# Altium schematic sheet Motor and ToF.SchDoc
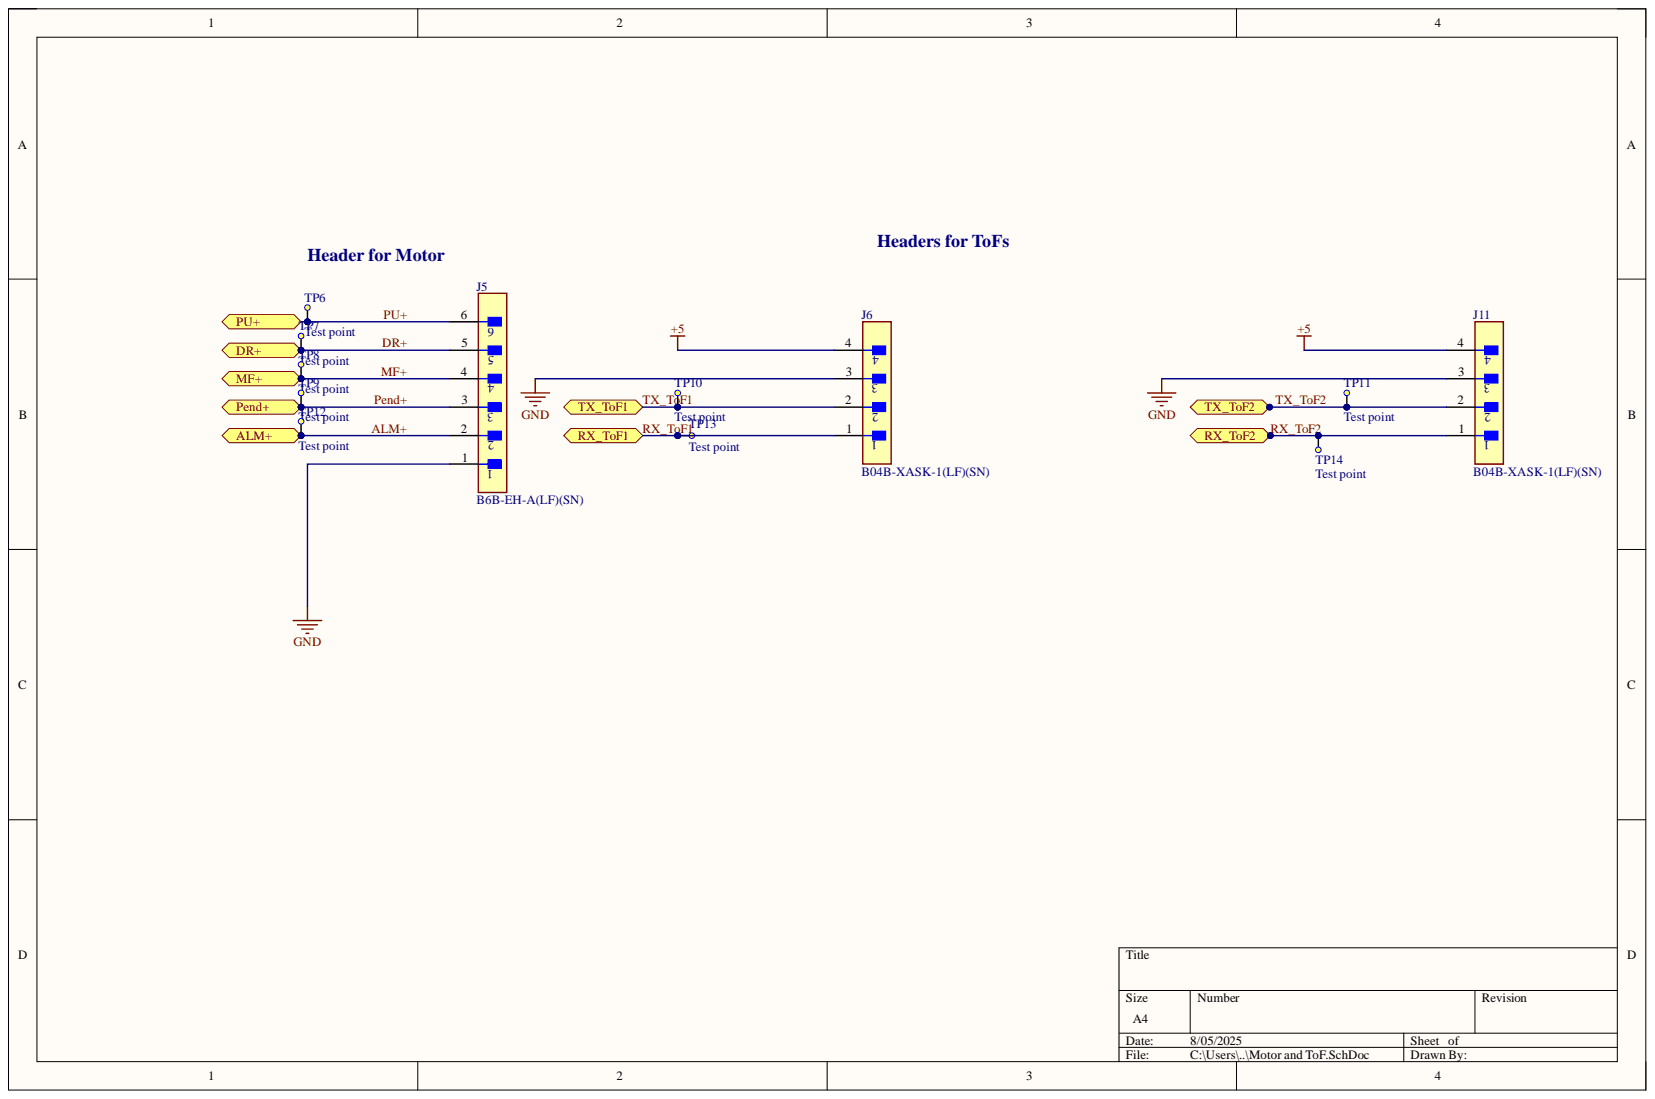
[diagram p0: Motor and ToF.SchDoc]

## Parts
J5  B6B-EH-A(LF)(SN)  [FP-B6B-EH-A_LF_SN-MFG]
J6  B04B-XASK-1(LF)(SN)  [FP-B04B-XASK-1_LF_SN-MFG]
J11  B04B-XASK-1(LF)(SN)  [FP-B04B-XASK-1_LF_SN-MFG]
TP6  Test point  [Test_point]
TP7  Test point  [Test_point]
TP8  Test point  [Test_point]
TP9  Test point  [Test_point]
TP10  Test point  [Test_point]
TP11  Test point  [Test_point]
TP12  Test point  [Test_point]
TP13  Test point  [Test_point]
TP14  Test point  [Test_point]

## Ports
ALM+, DR+, MF+, Pend+, PU+, RX_ToF1, RX_ToF2, TX_ToF1, TX_ToF2

## Nets
+5: J6.4 J11.4
GND: J5.1 J6.3 J11.3
ALM+: J5.2 TP12.TP? ; port ALM+
DR+: J5.5 TP7.TP? ; port DR+
MF+: J5.4 TP8.TP? ; port MF+
Pend+: J5.3 TP9.TP? ; port Pend+
PU+: J5.6 TP6.TP? ; port PU+
RX_ToF1: J6.1 TP13.TP? ; port RX_ToF1
RX_ToF2: J11.1 TP14.TP? ; port RX_ToF2
TX_ToF1: J6.2 TP10.TP? ; port TX_ToF1
TX_ToF2: J11.2 TP11.TP? ; port TX_ToF2

## Library data of the parts
J5 = CMP-17439-000293-1: CONN HEADER VERT 6POS 2.5MM; Current Rating: 3A; Gender: Male; Mated Stacking Heights: 8.1mm; Number of Contacts: 6; Voltage Rating: 250V; Color: Natural; Component Kind: Standard; Connector Type: Header; Contact Finish - Mating: TIN; Contact Material: Brass; Contact Mating Length: 0.13inch; Contact Plating: Tin; Contact Shape: Square; Contact Type: Male Pin; Fastening Type: Friction; Flammability Rating: UL94 V-0; Housing Color: White; Insulation Color: Natural; Insulation Material: Nylon; Lead Free: Lead Free; Library Name: Altium Content Vault; Mount: Through Hole; Number of Positions: 6; Number of Rows: 1; Orientation: Straight; Packaging: Bulk; Pitch: 2.5mm; Series: EH; Termination: Solder
J6 J11 = CMP-17439-000018-1: CONN HEADER VERT 4POS 2.5MM; Manufacturer: JST; Conn Gender: Male; Conn Orientation: Straight; Current Rating: 3A; Number Of Contacts: 4; Voltage Rating: 250V; Automotive: No; Category: Conn; Component Kind: Standard; ComponentLink2Description: Datasheet; ComponentLink2URL: http://www.jst-mfg.com/product/pdf/eng/eXA1.pdf; Contact Material: Tin,Copper; Device Class L1: Connectors; Device Class L2: Headers and Wire Housings; Device Class L3: unset; Digikey Description: CONN HEADER VERT 4POS 2.5MM; Height: 8.8mm; Lead Free: Yes; Library Name: Altium Content Vault; Manufacturer Part Number: B04B-XASK-1(LF)(SN); Number Of Rows: 1; Octopart: https://octopart.com/b04b-xask-1%28lf%29%28sn%29-jst-3990149; Package: B04B-XASK-1(LF)(SN); Pitch: 2.5mm; RoHS: TRUE; Temperature: -25°C to +85°C; Temperature Range High: +85°C; Temperature Range Low: -25°C
TP6-TP14 = test_point: Component Kind: Standard; Library Name: SL1.SchLib; Value: Test point
